# Altium schematic sheet Microcontroller.SchDoc(Microcontroller)
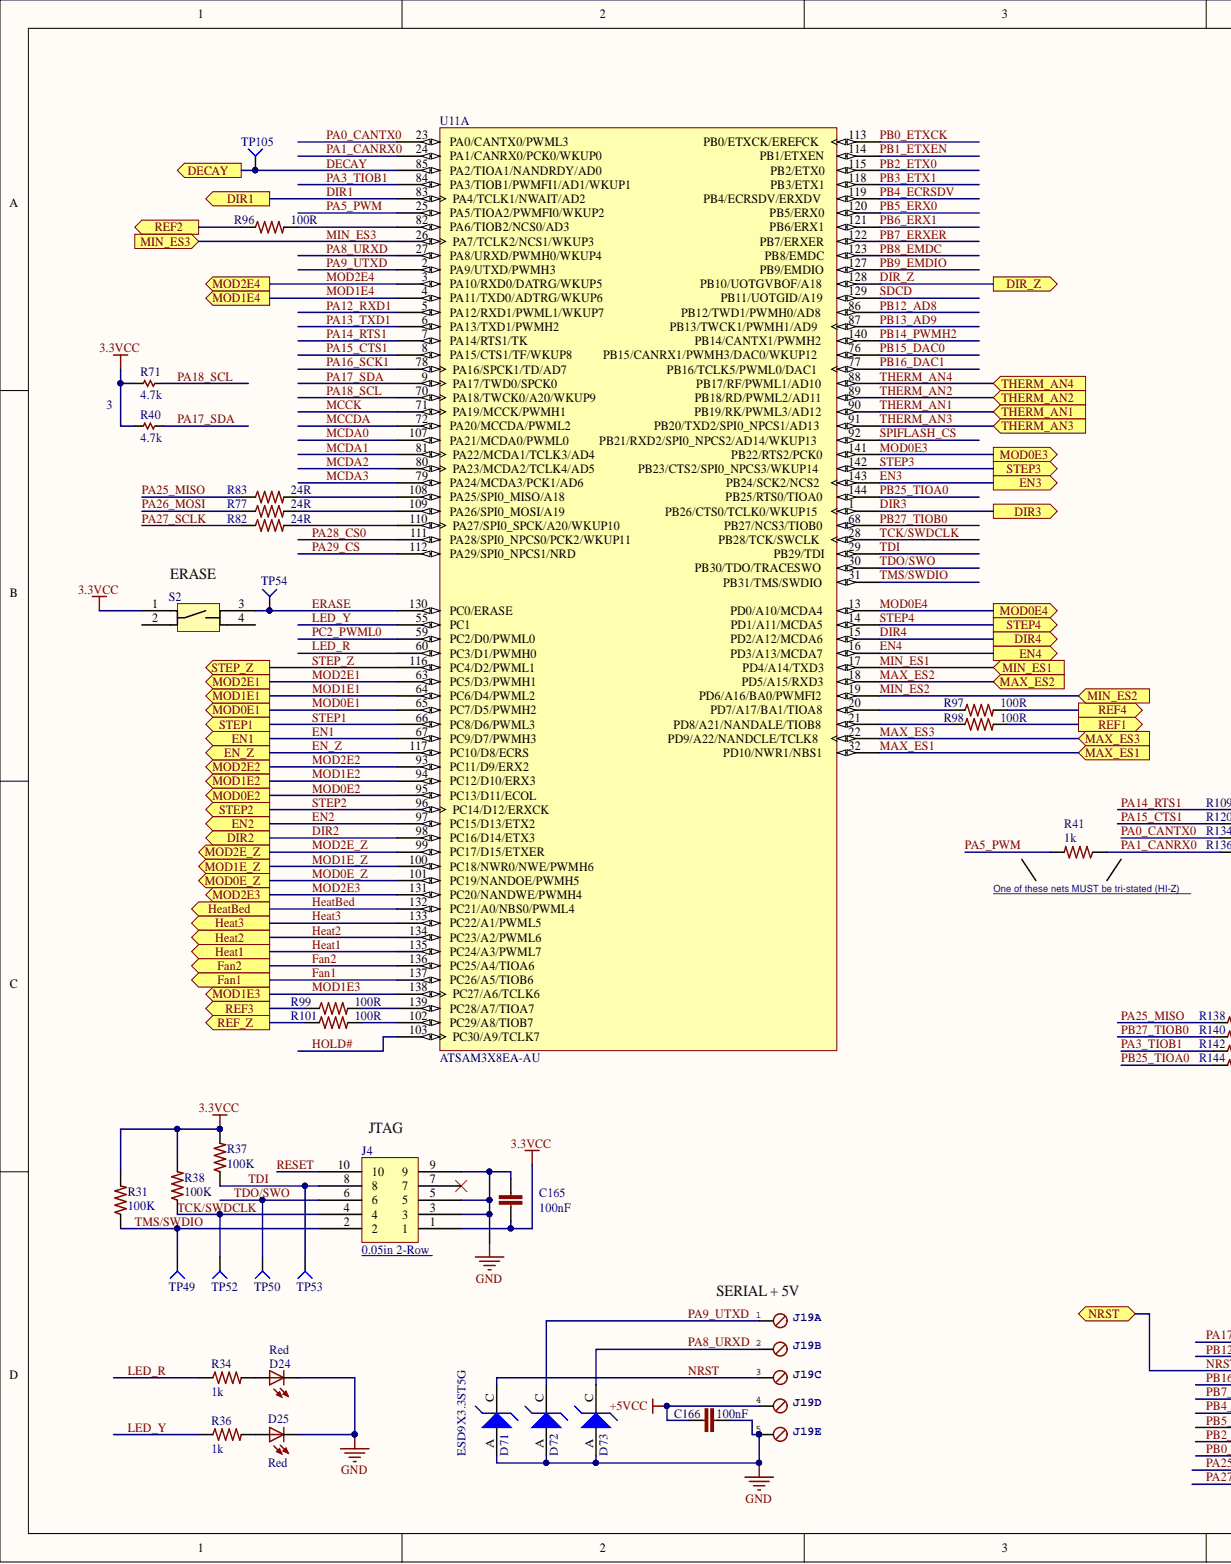
[diagram p0: Microcontroller.SchDoc, left]
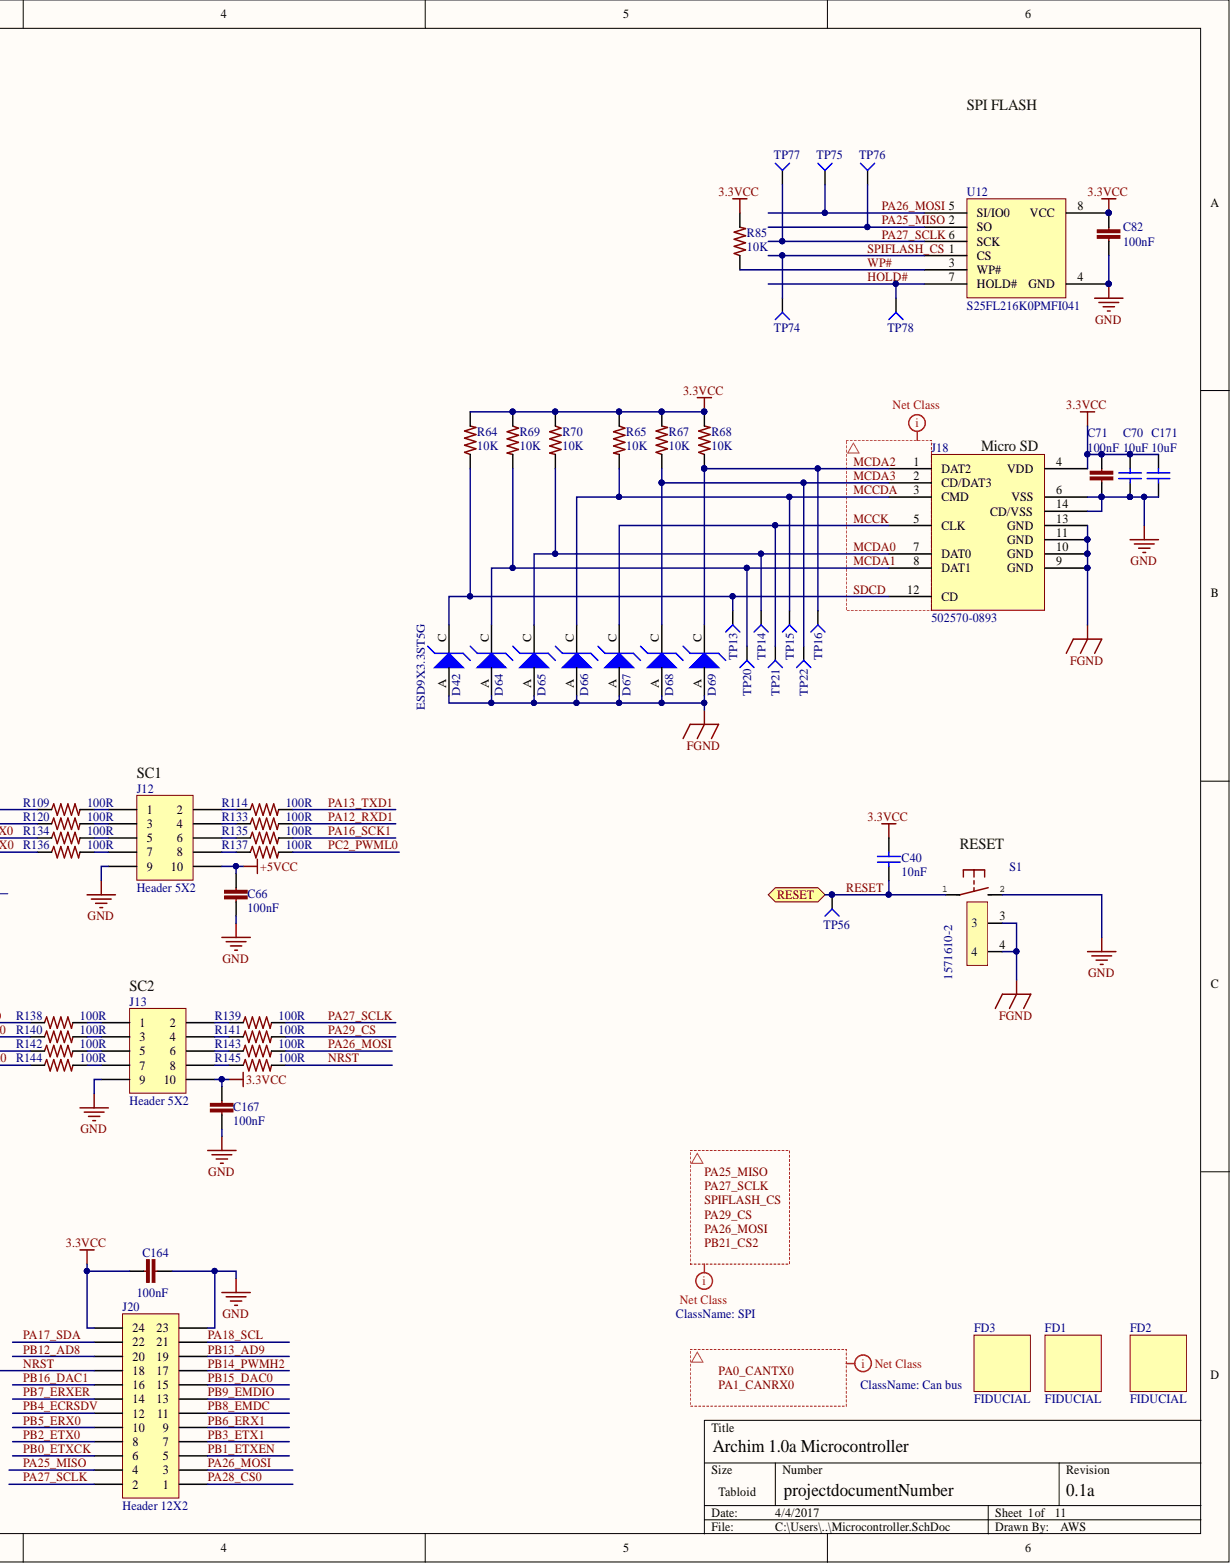
[diagram p1: Microcontroller.SchDoc, right]

## Parts
C40  10nF  [C0402]
C66  100nF  [C0402]
C70  value 10uF  [C0603]  (hidden comment: 0603)
C71  100nF  [C0402]
C82  100nF  [C0402]
C164  100nF  [C0402]
C165  100nF  [C0402]
C166  100nF  [C0402]
C167  100nF  [C0402]
C171  value 10uF  [C0603]  (hidden comment: 0603)
D24  Red  [LED2012120]
D25  Red  [LED2012120]
D42  ESD9X3.3ST5G  [SOD923-100X40-2N]
D64  ESD9X3.3ST5G  [SOD923-100X40-2N]
D65  ESD9X3.3ST5G  [SOD923-100X40-2N]
D66  ESD9X3.3ST5G  [SOD923-100X40-2N]
D67  ESD9X3.3ST5G  [SOD923-100X40-2N]
D68  ESD9X3.3ST5G  [SOD923-100X40-2N]
D69  ESD9X3.3ST5G  [SOD923-100X40-2N]
D71  ESD9X3.3ST5G  [SOD923-100X40-2N]
D72  ESD9X3.3ST5G  [SOD923-100X40-2N]
D73  ESD9X3.3ST5G  [SOD923-100X40-2N]
FD1  FIDUCIAL  [FIDUCIAL-1X2]
FD2  FIDUCIAL  [FIDUCIAL-1X2]
FD3  FIDUCIAL  [FIDUCIAL-1X2]
J4  0.05in 2-Row  [FTSH-105-XX-X-DV]
J12  Header 5X2  [HDR2X5]
J13  Header 5X2  [HDR2X5]
J18  502570-0893  [502570-0893 - Molex SD]
J19  0705430004  [5POL254]  (value not drawn)  (parts A,B,C,D,E)
J20  Header 12X2  [HDR2X12]
R31  100K  [R0402]
R34  1k  [R0402]
R36  1k  [R0402]
R37  100K  [R0402]
R38  100K  [R0402]
R40  4.7k  [R0402]
R41  1k  [R0402]
R64  10K  [R0402]
R65  10K  [R0402]
R67  10K  [R0402]
R68  10K  [R0402]
R69  10K  [R0402]
R70  10K  [R0402]
R71  4.7k  [R0402]
R77  24R  [R0402]
R82  24R  [R0402]
R83  24R  [R0402]
R85  10K  [R0402]
R96  100R  [R0402]
R97  100R  [R0402]
R98  100R  [R0402]
R99  100R  [R0402]
R101  100R  [R0402]
R109  100R  [R0402]
R114  100R  [R0402]
R120  100R  [R0402]
R133  100R  [R0402]
R134  100R  [R0402]
R135  100R  [R0402]
R136  100R  [R0402]
R137  100R  [R0402]
R138  100R  [R0402]
R139  100R  [R0402]
R140  100R  [R0402]
R141  100R  [R0402]
R142  100R  [R0402]
R143  100R  [R0402]
R144  100R  [R0402]
R145  100R  [R0402]
S1  1571610-2  [B3F-31XX]
S2  KMR741NG ULC LFS  [KMR 6 Series]  (value not drawn)
TP13  TP4  [TP-2]  (value not drawn)  (no BOM)
TP14  TP4  [TP-2]  (value not drawn)  (no BOM)
TP15  TP4  [TP-2]  (value not drawn)  (no BOM)
TP16  TP4  [TP-2]  (value not drawn)  (no BOM)
TP20  TP4  [TP-2]  (value not drawn)  (no BOM)
TP21  TP4  [TP-2]  (value not drawn)  (no BOM)
TP22  TP4  [TP-2]  (value not drawn)  (no BOM)
TP49  TP4  [TP-2]  (no BOM)
TP50  TP4  [TP-2]  (value not drawn)  (no BOM)
TP52  TP4  [TP-2]  (value not drawn)  (no BOM)
TP53  TP4  [TP-2]  (value not drawn)  (no BOM)
TP54  TP4  [TP-2]  (value not drawn)  (no BOM)
TP56  TP4  [TP-2]  (value not drawn)  (no BOM)
TP74  TP4  [TP-2]  (value not drawn)  (no BOM)
TP75  TP4  [TP-2]  (value not drawn)  (no BOM)
TP76  TP4  [TP-2]  (value not drawn)  (no BOM)
TP77  TP4  [TP-2]  (value not drawn)  (no BOM)
TP78  TP4  [TP-2]  (value not drawn)  (no BOM)
TP105  TP4  [TP-2]  (value not drawn)  (no BOM)
U11  ATSAM3X8EA-AU  [LQFP144_N]  (part A)
U12  S25FL216K0PMFI041  [S25FL216K0PMFI041]

## Ports
DECAY, DIR1, DIR2, DIR3, DIR4, DIR_Z, EN1, EN2, EN3, EN4, EN_Z, Fan1, Fan2, Heat1, Heat2, Heat3, HeatBed, MAX_ES1, MAX_ES2, MAX_ES3, MIN_ES1, MIN_ES2, MIN_ES3, MOD0E1, MOD0E2, MOD0E3, MOD0E4, MOD0E_Z, MOD1E1, MOD1E2, MOD1E3, MOD1E4, MOD1E_Z, MOD2E1, MOD2E2, MOD2E3, MOD2E4, MOD2E_Z, NRST, REF1, REF2, REF3, REF4, REF_Z, RESET, STEP1, STEP2, STEP3, STEP4, STEP_Z, THERM_AN1, THERM_AN2, THERM_AN3, THERM_AN4

## Nets
3.3VCC: C40.2 C70.2 C71.1 C82.1 C164.1 C165.2 C167.2 C171.2 J4.1 J13.10 J18.4(VDD) J20.24 R31.2 R37.2 R38.2 R40.1 R64.2 R65.1 R67.2 R68.2 R69.1 R70.1 R71.1 R85.2 S2.1 U12.8(VCC)
+5VCC: C66.2 C166.1 J12.10 J19.4
FGND: D42.A D64.A D65.A D66.A D67.A D68.A D69.A J18.9(GND) J18.10(GND) J18.11(GND) J18.13(GND) S1.3 S1.4
GND: C66.1 C70.1 C71.2 C82.2 C164.2 C165.1 C166.2 C167.1 C171.1 D24.C D25.C D71.A D72.A D73.A J4.3 J4.5 J4.9 J12.9 J13.9 J18.6(VSS) J18.14(CD/VSS) J19.5 J20.23 S1.2 U12.4(GND)
DECAY: TP105.1 U11.85(PA2/TIOA1/NANDRDY/AD0) ; port DECAY
DIR1: U11.83(PA4/TCLK1/NWAIT/AD2) ; port DIR1
DIR2: U11.98(PC16/D14/ETX3) ; port DIR2
DIR3: U11.1(PB26/CTS0/TCLK0/WKUP15) ; port DIR3
DIR4: U11.15(PD2/A12/MCDA6) ; port DIR4
DIR_Z: U11.128(PB10/UOTGVBOF/A18) ; port DIR_Z
EN1: U11.67(PC9/D7/PWMH3) ; port EN1
EN2: U11.97(PC15/D13/ETX2) ; port EN2
EN3: U11.143(PB24/SCK2/NCS2) ; port EN3
EN4: U11.16(PD3/A13/MCDA7) ; port EN4
EN_Z: U11.117(PC10/D8/ECRS) ; port EN_Z
ERASE: S2.3 TP54.1 U11.130(PC0/ERASE)
Fan1: U11.137(PC26/A5/TIOB6) ; port Fan1
Fan2: U11.136(PC25/A4/TIOA6) ; port Fan2
Heat1: U11.135(PC24/A3/PWML7) ; port Heat1
Heat2: U11.134(PC23/A2/PWML6) ; port Heat2
Heat3: U11.133(PC22/A1/PWML5) ; port Heat3
HeatBed: U11.132(PC21/A0/NBS0/PWML4) ; port HeatBed
HOLD#: TP78.1 U11.103(PC30/A9/TCLK7) U12.7(HOLD#)
LED_R: R34.1 U11.60(PC3/D1/PWMH0)
LED_Y: R36.1 U11.55(PC1)
MAX_ES1: U11.32(PD10/NWR1/NBS1) ; port MAX_ES1
MAX_ES2: U11.18(PD5/A15/RXD3) ; port MAX_ES2
MAX_ES3: U11.22(PD9/A22/NANDCLE/TCLK8) ; port MAX_ES3
MCCDA: D66.C J18.3(CMD) R65.2 TP15.1 U11.72(PA20/MCCDA/PWML2)
MCCK: D67.C J18.5(CLK) TP21.1 U11.71(PA19/MCCK/PWMH1)
MCDA0: D65.C J18.7(DAT0) R70.2 TP14.1 U11.107(PA21/MCDA0/PWML0)
MCDA1: D64.C J18.8(DAT1) R69.2 TP20.1 U11.81(PA22/MCDA1/TCLK3/AD4)
MCDA2: D69.C J18.1(DAT2) R68.1 TP16.1 U11.80(PA23/MCDA2/TCLK4/AD5)
MCDA3: D68.C J18.2(CD/DAT3) R67.1 TP22.1 U11.79(PA24/MCDA3/PCK1/AD6)
MIN_ES1: U11.17(PD4/A14/TXD3) ; port MIN_ES1
MIN_ES2: U11.19(PD6/A16/BA0/PWMFI2) ; port MIN_ES2
MIN_ES3: U11.26(PA7/TCLK2/NCS1/WKUP3) ; port MIN_ES3
MOD0E1: U11.65(PC7/D5/PWMH2) ; port MOD0E1
MOD0E2: U11.95(PC13/D11/ECOL) ; port MOD0E2
MOD0E3: U11.141(PB22/RTS2/PCK0) ; port MOD0E3
MOD0E4: U11.13(PD0/A10/MCDA4) ; port MOD0E4
MOD0E_Z: U11.101(PC19/NANDOE/PWMH5) ; port MOD0E_Z
MOD1E1: U11.64(PC6/D4/PWML2) ; port MOD1E1
MOD1E2: U11.94(PC12/D10/ERX3) ; port MOD1E2
MOD1E3: U11.138(PC27/A6/TCLK6) ; port MOD1E3
MOD1E4: U11.4(PA11/TXD0/ADTRG/WKUP6) ; port MOD1E4
MOD1E_Z: U11.100(PC18/NWR0/NWE/PWMH6) ; port MOD1E_Z
MOD2E1: U11.63(PC5/D3/PWMH1) ; port MOD2E1
MOD2E2: U11.93(PC11/D9/ERX2) ; port MOD2E2
MOD2E3: U11.131(PC20/NANDWE/PWMH4) ; port MOD2E3
MOD2E4: U11.3(PA10/RXD0/DATRG/WKUP5) ; port MOD2E4
MOD2E_Z: U11.99(PC17/D15/ETXER) ; port MOD2E_Z
NRST: D71.C J19.3 J20.18 R145.2 ; port NRST
PA0_CANTX0: R134.1 U11.23(PA0/CANTX0/PWML3)
PA1_CANRX0: R41.1 R136.1 U11.24(PA1/CANRX0/PCK0/WKUP0)
PA3_TIOB1: R142.1 U11.84(PA3/TIOB1/PWMFI1/AD1/WKUP1)
PA5_PWM: R41.2 U11.25(PA5/TIOA2/PWMFI0/WKUP2)
PA8_URXD: D73.C J19.2 U11.27(PA8/URXD/PWMH0/WKUP4)
PA9_UTXD: D72.C J19.1 U11.2(PA9/UTXD/PWMH3)
PA12_RXD1: R133.2 U11.5(PA12/RXD1/PWML1/WKUP7)
PA13_TXD1: R114.2 U11.6(PA13/TXD1/PWMH2)
PA14_RTS1: R109.1 U11.7(PA14/RTS1/TK)
PA15_CTS1: R120.1 U11.8(PA15/CTS1/TF/WKUP8)
PA16_SCK1: R135.2 U11.78(PA16/SPCK1/TD/AD7)
PA17_SDA: J20.22 R40.2 U11.9(PA17/TWD0/SPCK0)
PA18_SCL: J20.21 R71.2 U11.70(PA18/TWCK0/A20/WKUP9)
PA25_MISO: J20.4 R83.1 R138.1 TP76.1 U12.2(SO)
PA26_MOSI: J20.3 R77.1 R143.2 TP75.1 U12.5(SI/IO0)
PA27_SCLK: J20.2 R82.1 R139.2 TP77.1 U12.6(SCK)
PA28_CS0: J20.1 U11.111(PA28/SPI0_NPCS0/PCK2/WKUP11)
PA29_CS: R141.2 U11.112(PA29/SPI0_NPCS1/NRD)
PB0_ETXCK: J20.6 U11.113(PB0/ETXCK/EREFCK)
PB1_ETXEN: J20.5 U11.114(PB1/ETXEN)
PB2_ETX0: J20.8 U11.115(PB2/ETX0)
PB3_ETX1: J20.7 U11.118(PB3/ETX1)
PB4_ECRSDV: J20.12 U11.119(PB4/ECRSDV/ERXDV)
PB5_ERX0: J20.10 U11.120(PB5/ERX0)
PB6_ERX1: J20.9 U11.121(PB6/ERX1)
PB7_ERXER: J20.14 U11.122(PB7/ERXER)
PB8_EMDC: J20.11 U11.123(PB8/EMDC)
PB9_EMDIO: J20.13 U11.127(PB9/EMDIO)
PB12_AD8: J20.20 U11.86(PB12/TWD1/PWMH0/AD8)
PB13_AD9: J20.19 U11.87(PB13/TWCK1/PWMH1/AD9)
PB14_PWMH2: J20.17 U11.140(PB14/CANTX1/PWMH2)
PB15_DAC0: J20.15 U11.76(PB15/CANRX1/PWMH3/DAC0/WKUP12)
PB16_DAC1: J20.16 U11.77(PB16/TCLK5/PWML0/DAC1)
PB25_TIOA0: R144.1 U11.144(PB25/RTS0/TIOA0)
PB27_TIOB0: R140.1 U11.68(PB27/NCS3/TIOB0)
PC2_PWML0: R137.2 U11.59(PC2/D0/PWML0)
REF1: R98.2 ; port REF1
REF2: R96.1 ; port REF2
REF3: R99.1 ; port REF3
REF4: R97.2 ; port REF4
REF_Z: R101.1 ; port REF_Z
RESET: C40.1 J4.10 S1.1 TP56.1 ; port RESET
SDCD: D42.C J18.12(CD) R64.1 TP13.1 U11.129(PB11/UOTGID/A19)
SPIFLASH_CS: TP74.1 U11.92(PB21/RXD2/SPI0_NPCS2/AD14/WKUP13) U12.1(CS)
STEP1: U11.66(PC8/D6/PWML3) ; port STEP1
STEP2: U11.96(PC14/D12/ERXCK) ; port STEP2
STEP3: U11.142(PB23/CTS2/SPI0_NPCS3/WKUP14) ; port STEP3
STEP4: U11.14(PD1/A11/MCDA5) ; port STEP4
STEP_Z: U11.116(PC4/D2/PWML1) ; port STEP_Z
TCK/SWDCLK: J4.4 R38.1 TP52.1 U11.28(PB28/TCK/SWCLK)
TDI: J4.8 R37.1 TP53.1 U11.29(PB29/TDI)
TDO/SWO: J4.6 TP50.1 U11.30(PB30/TDO/TRACESWO)
THERM_AN1: U11.90(PB19/RK/PWML3/AD12) ; port THERM_AN1
THERM_AN2: U11.89(PB18/RD/PWML2/AD11) ; port THERM_AN2
THERM_AN3: U11.91(PB20/TXD2/SPI0_NPCS1/AD13) ; port THERM_AN3
THERM_AN4: U11.88(PB17/RF/PWML1/AD10) ; port THERM_AN4
TMS/SWDIO: J4.2 R31.1 TP49.1 U11.31(PB31/TMS/SWDIO)
WP#: R85.1 U12.3(WP#)
NetD24_A: D24.A R34.2
NetD25_A: D25.A R36.2
NetJ12_1: J12.1 R109.2
NetJ12_2: J12.2 R114.1
NetJ12_3: J12.3 R120.2
NetJ12_4: J12.4 R133.1
NetJ12_5: J12.5 R134.2
NetJ12_6: J12.6 R135.1
NetJ12_7: J12.7 R136.2
NetJ12_8: J12.8 R137.1
NetJ13_1: J13.1 R138.2
NetJ13_2: J13.2 R139.1
NetJ13_3: J13.3 R140.2
NetJ13_4: J13.4 R141.1
NetJ13_5: J13.5 R142.2
NetJ13_6: J13.6 R143.1
NetJ13_7: J13.7 R144.2
NetJ13_8: J13.8 R145.1
NetR77_2: R77.2 U11.109(PA26/SPI0_MOSI/A19)
NetR82_2: R82.2 U11.110(PA27/SPI0_SPCK/A20/WKUP10)
NetR83_2: R83.2 U11.108(PA25/SPI0_MISO/A18)
NetR96_2: R96.2 U11.82(PA6/TIOB2/NCS0/AD3)
NetR97_1: R97.1 U11.20(PD7/A17/BA1/TIOA8)
NetR98_1: R98.1 U11.21(PD8/A21/NANDALE/TIOB8)
NetR99_2: R99.2 U11.139(PC28/A7/TIOA7)
NetR101_2: R101.2 U11.102(PC29/A8/TIOB7)
N00067:
N00068:
N00069:
PB21_CS2:

Not connected: J4 7; S2 2 4

## Library data of the parts
C40 = GRM155R71H103JA88D: CAP CER 10nF 50V X7R 0402; PART DESCRIPTION: 10000pF ±5% 50V Ceramic Capacitor X7R 0402 (1005 Metric); MANUFACTURER: Murata; TOLERANCE: 5%; VALUE: 10nF; Simulation: CAP; Signal Integrity: Cap Semi; PART: 10nF 50V X7R 0402; MANUFACTURER #: GRM155R71H103JA88D; Library Name: Tigershark3D.SchLib; EE_SPEC: 50V; DISTRIBUTOR #: 490-7763-1-ND; DISTRIBUTOR: Digikey; Component Kind: Standard
C66 C71 C82 C164-C167 = GRM155R71C104KA88D: CAP CER 0402 100nF 16V 10% X7R; PART DESCRIPTION: 0.10µF ±10% 16V Ceramic Capacitor X7R 0402 (1005 Metric); PART: 0.1uF 16V X7R 0402; MANUFACTURER: Murata; VALUE: 100nF; TOLERANCE: 10%; Simulation: Model Name; Signal Integrity: Model Name; MANUFACTURER #: GRM155R71C104KA88D; Library Name: 3Delight.SCHLIB; EE_SPEC: 16V; DISTRIBUTOR #: 490-3261-2-ND; DISTRIBUTOR: Digikey; Component Kind: Standard
C70 C171 = C1608JB0J106K080AB: CAP CER 10UF 6.3V 10% JB 0603; PART: 10µF ±10% 6.3V Ceramic Capacitor JB 0603 (1608 Metric); MANUFACTURER: TDK; EE_SPEC: 6.3VDC; TOLERANCE: 10%; Simulation: CAP; Signal Integrity: Cap Semi; PART DESCRIPTION: CAP CER 10UF 6.3V 10% JB 0603; MANUFACTURER #: C1608JB0J106K080AB; Library Name: Tigershark3D.SchLib; DISTRIBUTOR #: 445-11185-1-ND; DISTRIBUTOR: Digikey; Component Kind: Standard
D24 = 5988110107F: LED 0805 Red; PART DESCRIPTION: Red 635nm LED Indication - Discrete 2.2V 0805 (2012 Metric); PART: LED Red 0805; MANUFACTURER: Dialight; TOLERANCE: -NA-; EE_SPEC: Vf=2.2V; VALUE: Red; MANUFACTURER #: 5988110107F; Library Name: 3Delight.SCHLIB; DISTRIBUTOR #: 350-2038-2-ND; DISTRIBUTOR: Digikey; Component Kind: Standard
D25 = 5988140107F: LED 0805 Yellow; PART DESCRIPTION: Red 635nm LED Indication - Discrete 2.2V 0805 (2012 Metric); PART: LED Red 0805; MANUFACTURER #: 5988110107F; MANUFACTURER: Dialight; TOLERANCE: -NA-; EE_SPEC: Vf=2.2V; VALUE: Red; Library Name: 3Delight.SCHLIB; DISTRIBUTOR #: 350-2038-2-ND; DISTRIBUTOR: Digikey; Component Kind: Standard
D42 D64-D69 D71-D73 = ESD9X3.3ST5G: TVS DIODE 3.3VWM 10.4VC SOD923; PART DESCRIPTION: TVS DIODE 3.3VWM SOD923; MANUFACTURER: On Semi; VALUE: 3.3V; TOLERANCE: -NA-; EE_SPEC: 3.3Vso 15pF; PART: TVS DIODE 3.3VWM SOD923; MANUFACTURER #: ESD9X3.3ST5G; DISTRIBUTOR #: ESD9X3.3ST5GOSCT-ND; DISTRIBUTOR: Digikey; Component Kind: Standard; Library Name: Archim_0.4.SCHLIB
FD1-FD3 = FIDUCIAL: MANUFACTURER #: NOTAPART-Fiducial; Library Name: 3Delight.SCHLIB; Component Kind: Standard
J4 = ARM Cortex JTAG-DEBUG Header: 20021121-00010C4LF; PART DESCRIPTION: 10 Positions Header, Unshrouded Connector 0.050" (1.27mm) Surface Mount Gold; PART: 10 Pin Conn 2Row SMT; MANUFACTURER: Amphenol FCI; VALUE: HDR10; TOLERANCE: -NA-; MANUFACTURER #: 20021121-00010C4LF; EE_SPEC: -NA-; DISTRIBUTOR #: 609-3695-2-ND; DISTRIBUTOR: Digikey; Component Kind: Standard; Library Name: Adams Altium Library.SchLib
J12 J13 = Header 5X2: Header, 5-Pin, Dual row; PART DESCRIPTION: Board-To-Board Connector, 2.54 mm, 10 Contacts, Header, 2213S Series, Through Hole, 2 Rows; PART: CONN 10Pin 2Row 0.1"; MANUFACTURER #: 2213S-10G; MANUFACTURER: Multicomp; VALUE: HDR10; TOLERANCE: -NA-; Signal Integrity: Connector; Library Name: Miscellaneous Connectors.IntLib; EE_SPEC: -NA-; DISTRIBUTOR #: 08N6791; DISTRIBUTOR: Future; Component Kind: Standard
J18 = 502570-0893: microSD 502570-0893 Molex Part; PART DESCRIPTION: 10 (8 + 2) Position Card Connector Secure Digital - microSD™ Surface Mount, Right Angle Gold; PART: CONN Micro SD; MANUFACTURER #: 5025700893; VALUE: SD Conn; TOLERANCE: -NA-; MANUFACTURER: Molex; Library Name: Tigershark3D.SchLib; EE_SPEC: -NA-; DISTRIBUTOR #: WM19093TR-ND; DISTRIBUTOR: Digikey; Component Kind: Standard
J19 = 0705430004: 5pos Latching 2.54mm; PART DESCRIPTION: 5 Positions Header, Shrouded Connector 0.100" (2.54mm) Through Hole Gold; PART: 5 Pin Conn; MANUFACTURER: Molex; VALUE: HDR5; TOLERANCE: -NA-; MANUFACTURER #: 0705430004; Library Name: 3Delight.SCHLIB; EE_SPEC: -NA-; DISTRIBUTOR #: WM4803-ND; DISTRIBUTOR: Digikey; Component Kind: Standard
J20 = Header 12X2: Header, 12-Pin, Dual row; PART DESCRIPTION: Board-To-Board Connector, 2.54 mm, 24 Contacts, Header, 2213S Series, Through Hole, 2 Rows; PART: CONN 24Pin 2Row 0.1"; MANUFACTURER #: 2213S-24G; MANUFACTURER: Multicomp; VALUE: HDR24; TOLERANCE: -NA-; Signal Integrity: Connector; Library Name: Miscellaneous Connectors.IntLib; EE_SPEC: -NA-; DISTRIBUTOR #: 08N6796; DISTRIBUTOR: Future; Component Kind: Standard
R31 R37 R38 = RC1005F104CS: RES SMD 100K OHM 1% 1/16W 0402; PART DESCRIPTION: 100k Ohm ±1% 0.063W, 1/16W Chip Resistor 0402 (1005 Metric) Moisture Resistant Thick Film; PART: 100k 1% 0402; MANUFACTURER: Samsung; VALUE: 100K; TOLERANCE: 1%; Simulation: Model Name; Signal Integrity: Model Name; MANUFACTURER #: RC1005F104CS; Library Name: Tigershark3D.SchLib; EE_SPEC: 1/16W; DISTRIBUTOR #: 1276-3432-1-ND; DISTRIBUTOR: Digikey; Component Kind: Standard
R34 R36 R41 = RK73H1ETTP1001F: RES 0402 1k 63mW 1%; PART DESCRIPTION: 1k Ohm ±1% 0.063W, 1/16W Chip Resistor 0402 (1005 Metric) Moisture Resistant Thick Film; PART: 1k 1% 0402; MANUFACTURER #: RC0402FR-071KL; MANUFACTURER: Yageo; EE_SPEC: 1/16W; VALUE: 1k; TOLERANCE: 1%; Simulation: Model Name; Signal Integrity: Model Name; Library Name: 3Delight.SCHLIB; DISTRIBUTOR #: 311-1.00KLRTR-ND; DISTRIBUTOR: Digikey; Component Kind: Standard
R40 R71 = RC0402FR-074K7L: RES SMD 4.7K OHM 1% 1/16W 0402; PART DESCRIPTION: 4.7k Ohm ±1% 0.063W, 1/16W Chip Resistor 0402 (1005 Metric) Moisture Resistant Thick Film; PART: 4.7k 1% 0402; MANUFACTURER: YAGEO; VALUE: 4.7k; TOLERANCE: 1%; MANUFACTURER #: RC0402FR-074K7L; Library Name: 3Delight.SCHLIB; EE_SPEC: 1/16W; DISTRIBUTOR #: 311-4.7KLRTR-ND; DISTRIBUTOR: Digikey; Component Kind: Standard
R64 R65 R67-R70 R85 = RC0402FR-0710KP: RES SMD 10K OHM 1% 1/16W 0402; PART DESCRIPTION: 10k Ohm ±1% 0.063W, 1/16W Chip Resistor 0402 (1005 Metric) Moisture Resistant Thick Film; PART: 10k 1% 0402; MANUFACTURER: Yageo; VALUE: 10K; TOLERANCE: 1%; Simulation: Model Name; Signal Integrity: Model Name; MANUFACTURER #: RC0402FR-0710KP; Library Name: 3Delight.SCHLIB; EE_SPEC: 1/16W; DISTRIBUTOR #: YAG1249TR-ND; DISTRIBUTOR: Digikey; Component Kind: Standard
R77 R82 R83 = CRCW040224R0FKED: Res Thick Film 0402 24 Ohm 1% 0.063W; PART DESCRIPTION: 24 Ohm ±1% 0.063W, 1/16W Chip Resistor 0402 (1005 Metric) Moisture Resistant Thick Film; PART: 24R 1% 0402; MANUFACTURER #: RC0402FR-0724RL; MANUFACTURER: Yageo; EE_SPEC: 1/16W; VALUE: 24R; TOLERANCE: 1%; Simulation: Model Name; Signal Integrity: Model Name; Library Name: 3Delight.SCHLIB; DISTRIBUTOR #: YAG3073TR-ND; DISTRIBUTOR: Digikey; Component Kind: Standard
R96-R99 R101 R109 R114 R120 R133-R145 = RES 100R 0402 1%: RES SMD 100 OHM 1% 1/16W 0402; PART DESCRIPTION: 100 Ohm ±1% 0.063W, 1/16W Chip Resistor 0402 (1005 Metric) Moisture Resistant Thick Film; PART: 100R 1% 0402; MANUFACTURER #: RC0402FR-07100RL; MANUFACTURER: Yageo; VALUE: 100R; TOLERANCE: 1%; EE_SPEC: 1/16W; DISTRIBUTOR #: 311-100LRTR-ND; DISTRIBUTOR: Digikey; Component Kind: Standard; Library Name: Archim.SCHLIB
S1 = 1571610-2: SWTCH Momentary Washable; PART DESCRIPTION: Tactile Switches R/A SEALED TACT SWITCH; PART: Tactile Pushbutton; MANUFACTURER: TE; VALUE: -NA-; EE_SPEC: 24V; TOLERANCE: -NA-; MANUFACTURER #: 1571610-2; Library Name: 3Delight.SCHLIB; DISTRIBUTOR #: 506-1571610-2; DISTRIBUTOR: Mouser; Component Kind: Standard
S2 = KMR741NG ULC LFS: SWITCH TACTILE SPST-NO 0.05A 12V; PART DESCRIPTION: Tactile Switch SPST-NO Top Actuated Surface Mount; PART: Tactile Pushbutton SMT; MANUFACTURER: C&K; VALUE: -NA-; EE_SPEC: 32V 50mA; TOLERANCE: -NA-; MANUFACTURER #: KMR741NG ULC LFS; Library Name: 3Delight.SCHLIB; DISTRIBUTOR #: CKN10688TR-ND; DISTRIBUTOR: Digikey; Component Kind: Standard
TP13-TP16 TP20-TP22 TP49 TP50 TP52-TP54 TP56 TP74-TP78 TP105 = Socket: MANUFACTURER #: NOTAPART-Testpoint; Signal Integrity: Connector; Description: Socket; Library Name: Archim.SCHLIB
U11 = ATML-ATSAM3X-LQFP-144: Atmel; PART DESCRIPTION: ARM® Cortex®-M3 SAM3X Microcontroller IC 32-Bit 84MHz 512KB (512K x 8) FLASH 144-LQFP (20x20); PART: IC MCU 32BIT 512KB FLASH 144LQFP; VALUE: -NA-; EE_SPEC: 3.3V; TOLERANCE: -NA-; MANUFACTURER #: ATSAM3X8EA-AU; MANUFACTURER: Atmel; DISTRIBUTOR #: ATSAM3X8EA-AU-ND; DISTRIBUTOR: Digikey; Component Kind: Standard
U12 = S25FL216K0PMFI041: PART DESCRIPTION: Flash Memory 16Mb 3V 65MHz Serial NOR Flash; PART: Memory NOR Flash 16Mb; MANUFACTURER: Spansion; VALUE: 16Mb; TOLERANCE: -NA-; EE_SPEC: 3.3V; MANUFACTURER #: S25FL216K0PMFI041; Library Name: Tigershark3D.SchLib; DISTRIBUTOR #: 797-25FL216K0PMFI041; DISTRIBUTOR: Mouser; Component Kind: Standard
